# Altium schematic sheet ct_frontend.SchDoc
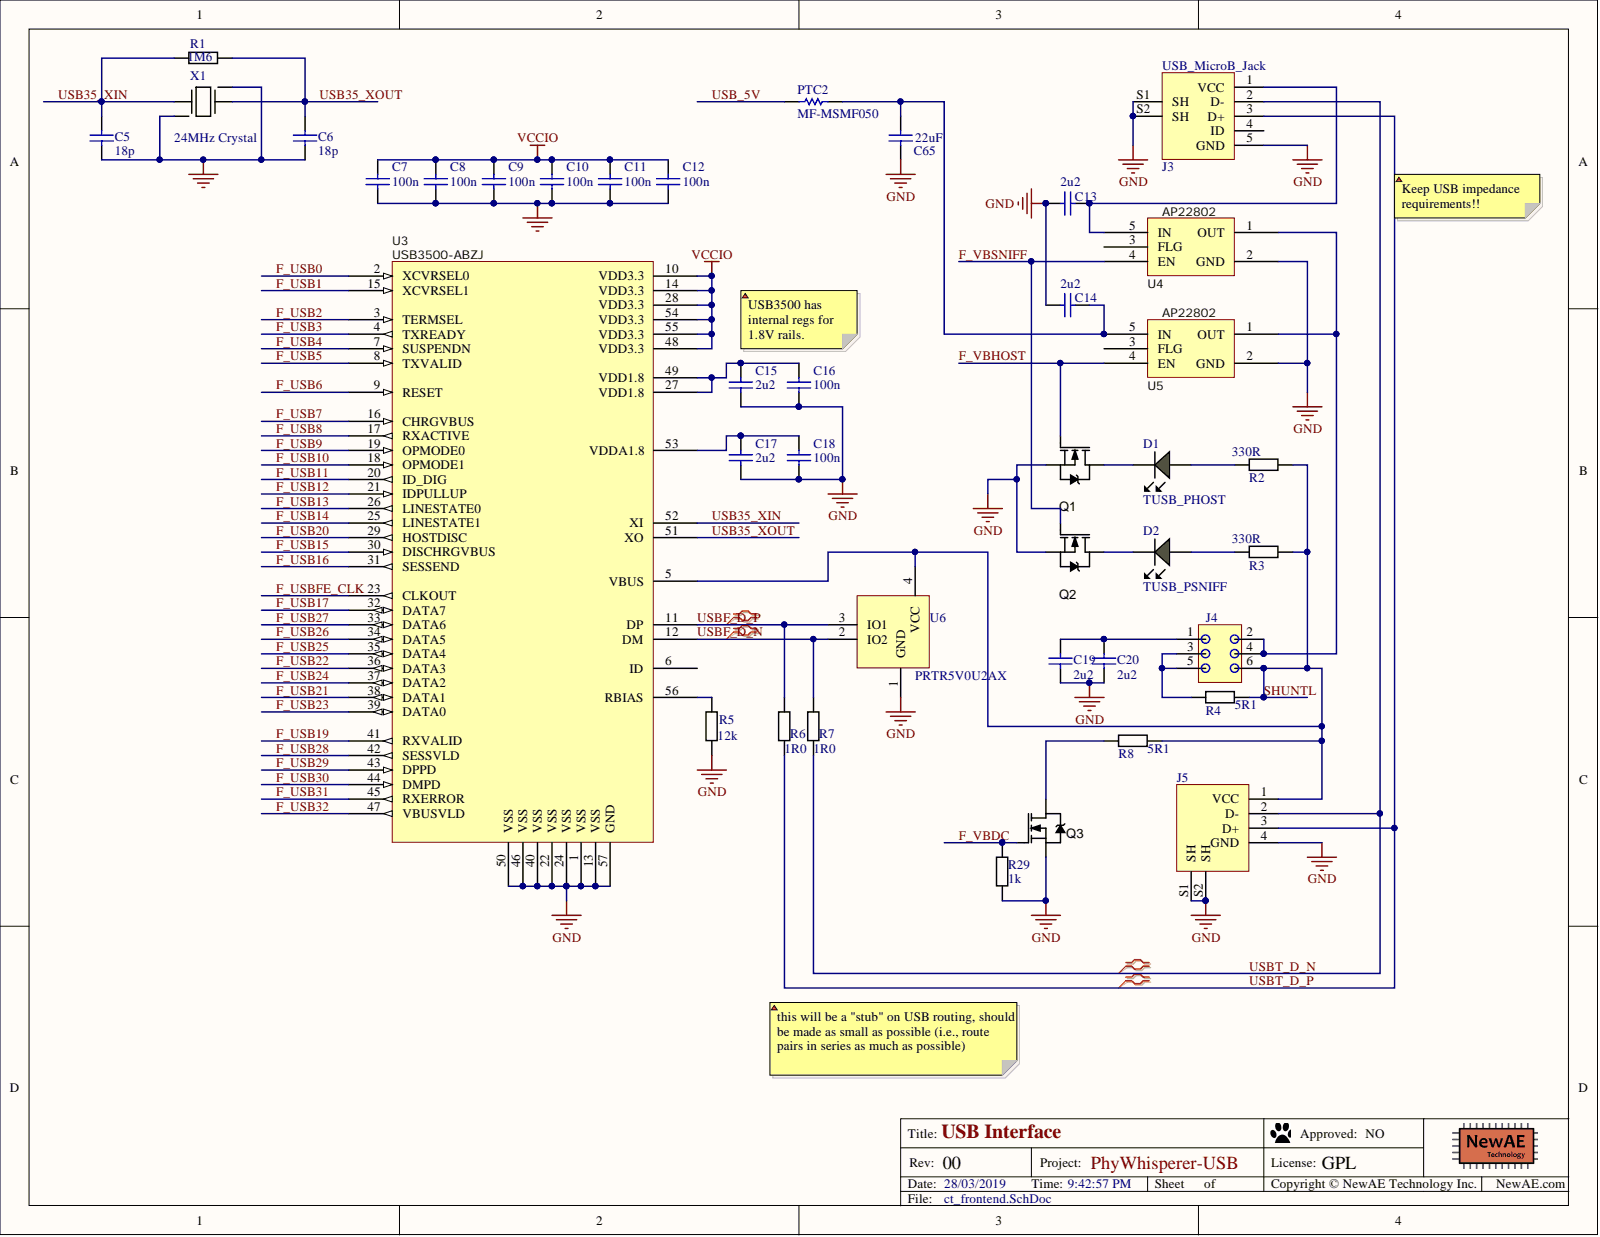
[diagram p0: ct_frontend.SchDoc]

## Parts
C5  18p  [0402]
C6  18p  [0402]
C7  100n  [0603]
C8  100n  [0603]
C9  100n  [0603]
C10  100n  [0603]
C11  100n  [0603]
C12  100n  [0603]
C13  2u2  [0603]
C14  2u2  [0603]
C15  2u2  [0603]
C16  100n  [0603]
C17  2u2  [0603]
C18  100n  [0603]
C19  2u2  [0603]
C20  2u2  [0603]
C65  22uF  [0805]
D1  TUSB_PHOST  [LED-0603-GREEN]
D2  TUSB_PSNIFF  [LED-0603-GREEN]
J3  USB_MicroB_Jack  [ZX62D-B-5P8(30)]
J4  TSW-103-08-F-D-RA  [HDR_6PIN_2ROW_UNSHROUDED]  (value not drawn)
J5  USB-A-S-F-W-TH  [USB-A-S-F-W-TH]  (value not drawn)
PTC2  MF-MSMF050  [BOUR-MF-MSMF050-2_V]
Q1  DMN3200U-7  [SOT23]  (value not drawn)
Q2  DMN3200U-7  [SOT23]  (value not drawn)
Q3  DMN3200U-7  [SOT23]  (value not drawn)
R1  1M6  [0603]
R2  330R  [0603]
R3  330R  [0603]
R4  5R1  [0805]
R5  12k  [0603]
R6  1R0  [0603]
R7  1R0  [0603]
R8  5R1  [0805]
R29  1k  [0603]
U3  USB3500-ABZJ  [QFN56]
U4  AP22802  [SOT25]
U5  AP22802  [SOT25]
U6  PRTR5V0U2AX  [SOT143B]
X1  24MHz Crystal  Component Group: Crystal  [ABM8G]

## Nets
GND: C5.2 C6.2 C7.2 C8.2 C9.2 C10.2 C11.2 C12.2 C13.1 C14.1 C15.2 C16.2 C17.2 C18.2 C19.1 C20.1 C65.2 J3.5(GND) J3.S1(SH) J3.S2(SH) J5.4(GND) J5.S1(SH) J5.S2(SH) Q1.2 Q2.2 Q3.2 R5.2 R29.1 U3.1(VSS) U3.13(VSS) U3.22(VSS) U3.24(VSS) U3.40(VSS) U3.46(VSS) U3.50(VSS) U3.57(GND) U4.2(GND) U5.2(GND) U6.1(GND) X1.2 X1.4
VCCIO: C7.1 C8.1 C9.1 C10.1 C11.1 C12.1 U3.10(VDD3.3) U3.14(VDD3.3) U3.28(VDD3.3) U3.48(VDD3.3) U3.54(VDD3.3) U3.55(VDD3.3)
F_USB0: U3.2(XCVRSEL0)
F_USB1: U3.15(XCVRSEL1)
F_USB2: U3.3(TERMSEL)
F_USB3: U3.4(TXREADY)
F_USB4: U3.7(SUSPENDN)
F_USB5: U3.8(TXVALID)
F_USB6: U3.9(RESET)
F_USB7: U3.16(CHRGVBUS)
F_USB8: U3.17(RXACTIVE)
F_USB9: U3.19(OPMODE0)
F_USB10: U3.18(OPMODE1)
F_USB11: U3.20(ID_DIG)
F_USB12: U3.21(IDPULLUP)
F_USB13: U3.26(LINESTATE0)
F_USB14: U3.25(LINESTATE1)
F_USB15: U3.30(DISCHRGVBUS)
F_USB16: U3.31(SESSEND)
F_USB17: U3.32(DATA7)
F_USB19: U3.41(RXVALID)
F_USB20: U3.29(HOSTDISC)
F_USB21: U3.38(DATA1)
F_USB22: U3.36(DATA3)
F_USB23: U3.39(DATA0)
F_USB24: U3.37(DATA2)
F_USB25: U3.35(DATA4)
F_USB26: U3.34(DATA5)
F_USB27: U3.33(DATA6)
F_USB28: U3.42(SESSVLD)
F_USB29: U3.43(DPPD)
F_USB30: U3.44(DMPD)
F_USB31: U3.45(RXERROR)
F_USB32: U3.47(VBUSVLD)
F_USBFE_CLK: U3.23(CLKOUT)
F_VBDC: Q3.1 R29.2
F_VBHOST: Q1.1 U5.4(EN)
F_VBSNIFF: Q2.1 U4.4(EN)
SHUNTL: J4.6 J5.1(VCC) R2.2 R3.2 R4.2 R8.2 U3.5(VBUS) U6.4(VCC)
USB35_XIN: C5.1 R1.1 U3.52(XI) X1.1
USB35_XOUT: C6.1 R1.2 U3.51(XO) X1.3
USB_5V: PTC2.1
USBF_D_N: R7.1 U3.12(DM) U6.2(IO2)
USBF_D_P: R6.1 U3.11(DP) U6.3(IO1)
USBT_D_N: J3.2(D-) J5.2(D-) R7.2
USBT_D_P: J3.3(D+) J5.3(D+) R6.2
NetC13_2: C13.2 J3.1(VCC) U4.5(IN)
NetC14_2: C14.2 C65.1 PTC2.2 U5.5(IN)
NetC15_1: C15.1 C16.1 U3.27(VDD1.8) U3.49(VDD1.8)
NetC17_1: C17.1 C18.1 U3.53(VDDA1.8)
NetC19_2: C19.2 C20.2 J4.1
NetD1_A: D1.A R2.1
NetD1_K: D1.K Q1.3
NetD2_A: D2.A R3.1
NetD2_K: D2.K Q2.3
NetJ4_2: J4.2 J4.4 U4.1(OUT) U5.1(OUT)
NetJ4_3: J4.3 J4.5 R4.1
NetQ3_3: Q3.3 R8.1
NetR5_1: R5.1 U3.56(RBIAS)

Not connected: J3 4(ID); U3 6(ID); U4 3(FLG); U5 3(FLG)

## Library data of the parts
C5 C6 = CCHIP-18p-0402: 18p, 0402; Manufacture Part Number 1: GRM1555C1H180JA01D; Component Group: Capacitor; Database Table Name: main; Case-EIA: 0402; Component Kind: Standard; Component Type: Standard; Library Name: NewAE_AltiumLib.DBLib; Mounting Technology: SMT; Part Number: CCHIP-18p-0402; Pin Count: 2; Value: 18p
C7-C12 C16 C18 = CCHIP-100n-0603: 100n, 0603; Manufacture 1: Kemet; Manufacture Part Number 1: C0603C104K5RACTU; Case-Metric: 1608; Component Group: Capacitor; Database Table Name: main; Rated Voltage: 50VDC; Case-EIA: 0603; Component Kind: Standard; Component Type: Standard; Library Name: NewAE_AltiumLib.DBLib; Mounting Technology: SMT; Part Number: CCHIP-100n-0603; Pin Count: 2; Supplier 1: Digi-Key; Supplier Part Number 1: 399-5089-2-ND; Value: 100n
C13-C15 C17 C19 C20 = CCHIP-2u2-0603: 2u2, 0603; Manufacture 1: Samsung; Manufacture Part Number 1: CL10B225KP8NNNC; Case-Metric: 1608; Component Group: Capacitor; Database Table Name: main; Rated Voltage: 10VDC; Case-EIA: 0603; Component Kind: Standard; Component Type: Standard; Library Name: NewAE_AltiumLib.DBLib; Mounting Technology: SMT; Part Number: CCHIP-2u2-0603; Pin Count: 2; Supplier 1: Digi-Key; Supplier Part Number 1: 1276-1134-2-ND; Value: 2u2
C65 = CCHIP-22u-0805-16V: 22u, 16V, 0805, Ceramic; Manufacture 1: Samsung Electro-Mechanics; Manufacture Part Number 1: CL21A226MOCLRNC; Case-Metric: 1608; Component Group: Capacitor; Database Table Name: main; Rated Voltage: 16VDC; Tolerance: 20%; Case-EIA: 0805; Component Kind: Standard; Component Type: Standard; Library Name: NewAE_AltiumLib.DBLib; Mounting Technology: SMT; Part Number: CCHIP-22u-0805-16V; Pin Count: 2; RoHS: Yes; Supplier 1: Digi-Key; Supplier Part Number 1: 1276-6780-1-ND; Value: 22uF
D1 D2 = LEDCHIP_0603_GREEN: Chip LED, Green; Manufacture 1: Kingbright; Manufacture Part Number 1: APT1608CGCK; Component Group: Optical; Database Table Name: main; PP Material Stack: 16; PP Rotation: -90; Component Kind: Standard; Component Type: Standard; Library Name: NewAE_AltiumLib.DBLib; Mounting Technology: SMT; Part Number: LEDCHIP_0603_GREEN; Pin Count: 2; Supplier 1: Digi-Key; Supplier Part Number 1: 754-1116-1-ND
J3 = ZX62D-B-5P8(30): CONN RCPT USB MICRO B SMD; Manufacture 1: Hirose Electric Co Ltd; Component Group: Connector; Database Table Name: main; PP Material Stack: 0; Component Kind: Standard; Component Type: Standard; Library Name: NewAE_AltiumLib.DBLib; Manufacture Part Number 1: ZX62D-B-5P8(30); Mounting Technology: Through-hole; Part Number: ZX62D-B-5P8(30); Pin Count: 5; PP Rotation: 0; RoHS: Yes; Supplier 1: Digi-Key; Supplier Part Number 1: H125272CT-ND
J4 = HDR_6PIN_2ROW_RA_UNSHROUDED: 2x3 Header, R/A, Unshrouded; Manufacture 1: Samtec; Component Group: Connector; Database Table Name: main; PP Material Stack: 0; Component Kind: Standard; Component Type: Standard; Library Name: NewAE_AltiumLib.DBLib; Manufacture Part Number 1: TSW-103-08-F-D-RA; Part Number: HDR_6PIN_2ROW_RA_UNSHROUDED; Pin Count: 6; PP Rotation: 0
J5 = USB-A-S-F-W-TH: Female USB-A; Manufacture 1: Samtec; Component Group: Connector; Database Table Name: main; PP Material Stack: 0; Component Kind: Standard; Component Type: Standard; Library Name: NewAE_AltiumLib.DBLib; Manufacture Part Number 1: USB-A-S-F-W-TH; Part Number: USB-A-S-F-W-TH; Pin Count: 6; PP Rotation: 0
PTC2 = CMP-0280-00002-2: MF-MSMF Series - PTC Resettable Fuse, 15 V Max, 0.15 Ohm Min, -40 to 85 degC, RoHS, Reel; Manufacturer: Bourns; Current Rating (Max): 100 A; Hold Current: 0.5 A; Trip Current: 1 A; Voltage DC (Max): 15 V; Component Kind: Standard; ComponentLink1Description: Manufacturer URL; ComponentLink1URL: http://www.bourns.com/; ComponentLink2Description: Datasheet; ComponentLink2URL: http://www.bourns.com/data/global/pdfs/mfmsmf.pdf; ComponentLink3Description: Package Specification; ComponentLink3URL: http://www.bourns.com/data/global/pdfs/mfmsmf.pdf; DatasheetVersion: Rev. AD; Library Name: Altium Content Vault; Mounting Technology: Surface Mount; Operating Temperature Range: -40 to 85 degC; PackageDescription: 2-Pin SMD, Body 4.55 x 3.24 mm; PackageReference: MF-MSMF050; Packing: Reel; PartNumber: MF-MSMF050; Resistance (Min): 0.15 Ohm; RoHS: TRUE; Signal Integrity: Guessed Model; Supplier 1: Digi-Key; Supplier Part Number 1: MF-MSMF050-2CT-ND
Q1-Q3 = DMN3200U-7: MOSFET N-CH 30V 2.2A SOT23-3; Manufacture 1: Diodes Inc.; Database Table Name: main; PP Material Stack: 0; Rated Power: 3A; Component Group: MOSFET; Component Kind: Standard; Component Type: Standard; Library Name: NewAE_AltiumLib.DBLib; Manufacture Part Number 1: DMN3200U-7; Mounting Technology: SMT; Part Number: DMN3200U-7; Pin Count: 3; PP Rotation: 0; Rated Voltage: 30V; RoHS: Yes; Supplier 1: Digi-Key; Supplier Part Number 1: DMN3200U-7DICT-ND
R1 = RCHIP-1M6-0603: 1M6 res, 0603, 1%, 1/10W; Manufacture 1: Yageo; Manufacture Part Number 1: RC0603FR-071M6L; Case-Metric: 1608; Component Group: Resistor; Database Table Name: main; Rated Power: 0.1W; Rated Voltage: 75VDC; Case-EIA: 0603; Component Kind: Standard; Component Type: Standard; Library Name: NewAE_AltiumLib.DBLib; Mounting Technology: SMT; Part Number: RCHIP-1M6-0603; Pin Count: 2; RoHS: Yes; Supplier 1: Digi-Key; Supplier Part Number 1: YAG3327CT-ND; Temperature Range: -55C to 155C; Tolerance: 1%; Value: 1M6
R2 R3 = RCHIP-330R-0603: 330R res, 0603, 1%, 1/10W; Manufacture 1: Yageo; Manufacture Part Number 1: RC0603FR-07330RL; Case-Metric: 1608; Component Group: Resistor; Database Table Name: main; Rated Power: 0.1W; Rated Voltage: 75VDC; Case-EIA: 0603; Component Kind: Standard; Component Type: Standard; Library Name: NewAE_AltiumLib.DBLib; Mounting Technology: SMT; Part Number: RCHIP-330R-0603; Pin Count: 2; Supplier 1: Digi-Key; Supplier Part Number 1: 311-330HRCT-ND; Temperature Range: -55C to 155C; Tolerance: 1%; Value: 330R
R4 R8 = RCHIP-5R1-0805: 5R1  res, 0805, 1%; Component Group: Resistor; Database Table Name: main; Case-EIA: 0805; Component Kind: Standard; Component Type: Standard; Library Name: NewAE_AltiumLib.DBLib; Part Number: RCHIP-5R1-0805; Pin Count: 2; Value: 5R1
R5 = RCHIP-12K-0603: 12k res, 0603, 1%, 1/10W; Manufacture 1: Yageo; Manufacture Part Number 1: RC0603FR-0712KL; Case-Metric: 1608; Component Group: Resistor; Database Table Name: main; Rated Power: 0.1W; Rated Voltage: 75VDC; Case-EIA: 0603; Component Kind: Standard; Component Type: Standard; Library Name: NewAE_AltiumLib.DBLib; Mounting Technology: SMT; Part Number: RCHIP-12K-0603; Pin Count: 2; Supplier 1: Digi-Key; Supplier Part Number 1: 311-12.0KHRCT-ND; Temperature Range: -55C to 155C; Tolerance: 1%; Value: 12k
R6 R7 = RCHIP-1R0-0603: 1R0 res, 0603, 1%, 1/10W; Manufacture 1: Yageo; Manufacture Part Number 1: RC0603FR-071RL; Case-Metric: 1608; Component Group: Resistor; Database Table Name: main; Rated Power: 0.1W; Rated Voltage: 75VDC; Case-EIA: 0603; Component Kind: Standard; Component Type: Standard; Library Name: NewAE_AltiumLib.DBLib; Mounting Technology: SMT; Part Number: RCHIP-1R0-0603; Pin Count: 2; Supplier 1: Digi-Key; Supplier Part Number 1: 311-1.00HRCT-ND; Temperature Range: -55C to 155C; Tolerance: 1%; Value: 1R0
R29 = RCHIP-1K-0603: 1k res, 0603, 1%, 1/10W; Manufacture 1: Yageo; Manufacture Part Number 1: RC0603FR-071KL; Case-Metric: 1608; Component Group: Resistor; Database Table Name: main; Rated Power: 0.1W; Rated Voltage: 75VDC; Case-EIA: 0603; Component Kind: Standard; Component Type: Standard; Library Name: NewAE_AltiumLib.DBLib; Mounting Technology: SMT; Part Number: RCHIP-1K-0603; Pin Count: 2; Supplier 1: Digi-Key; Supplier Part Number 1: 311-1.00KHRCT-ND; Temperature Range: -55C to 155C; Tolerance: 1%; Value: 1k
U3 = USB3500: USB Phy, UTMI 3+; Manufacture 1: Texas Instruments; Company_PN: <Enter Company P/N>; Component Group: Digital IC; Database Table Name: main; Pitch: 0.5mm; PP Material Stack: 0; Component Kind: Standard; Component Type: Standard; Library Name: NewAE_AltiumLib.DBLib; Manufacture Part Number 1: USB3500-ABZJ; Mounting Technology: SMT; Part Number: USB3500; Pin Count: 57; PP Rotation: 0; RoHS: Yes; Supplier 1: Digi-Key; Supplier Part Number 1: 638-1035-ND; Created By: D.GODDARD
U4 U5 = AP22802: USB Power Switch, Digital IC; Manufacture 1: Diodes Inc; Company_PN: <Enter Company P/N>; Component Group: Analog IC; Database Table Name: main; PP Material Stack: 0; Component Kind: Standard; Component Type: Standard; Library Name: NewAE_AltiumLib.DBLib; Manufacture Part Number 1: AP22802; Part Number: AP22802; Pin Count: 5; PP Rotation: 0; Supplier 1: Digi-Key; Supplier Part Number 1: AP22802AW5-7DICT-ND; Created By: D.GODDARD
U6 = PRTR5V0U2AX: USB 2.0 high-speed ESD; Component Group: Diode; Database Table Name: main; Component Kind: Standard; Component Type: Standard; Library Name: NewAE_AltiumLib.DBLib; Part Number: PRTR5V0U2AX; Pin Count: 4; Supplier 1: Digi-Key; Supplier Part Number 1: 568-7445-1-ND
X1 = ABM8G: CRYSTAL 24.0000MHZ 10PF SMD; Manufacture 1: Abracon LLC; Manufacture Part Number 1: ABM8G-12.000MHZ-4Y-T3; Database Table Name: main; PP Material Stack: 0; Component Kind: Standard; Component Type: Standard; Library Name: NewAE_AltiumLib.DBLib; Mounting Technology: SMT; Part Number: ABM8G; Pin Count: 4; PP Rotation: 0; RoHS: Yes; Supplier 1: Digi-Key; Supplier Part Number 1: 535-10901-1-ND
